# Altium schematic sheet CONN.SchDoc
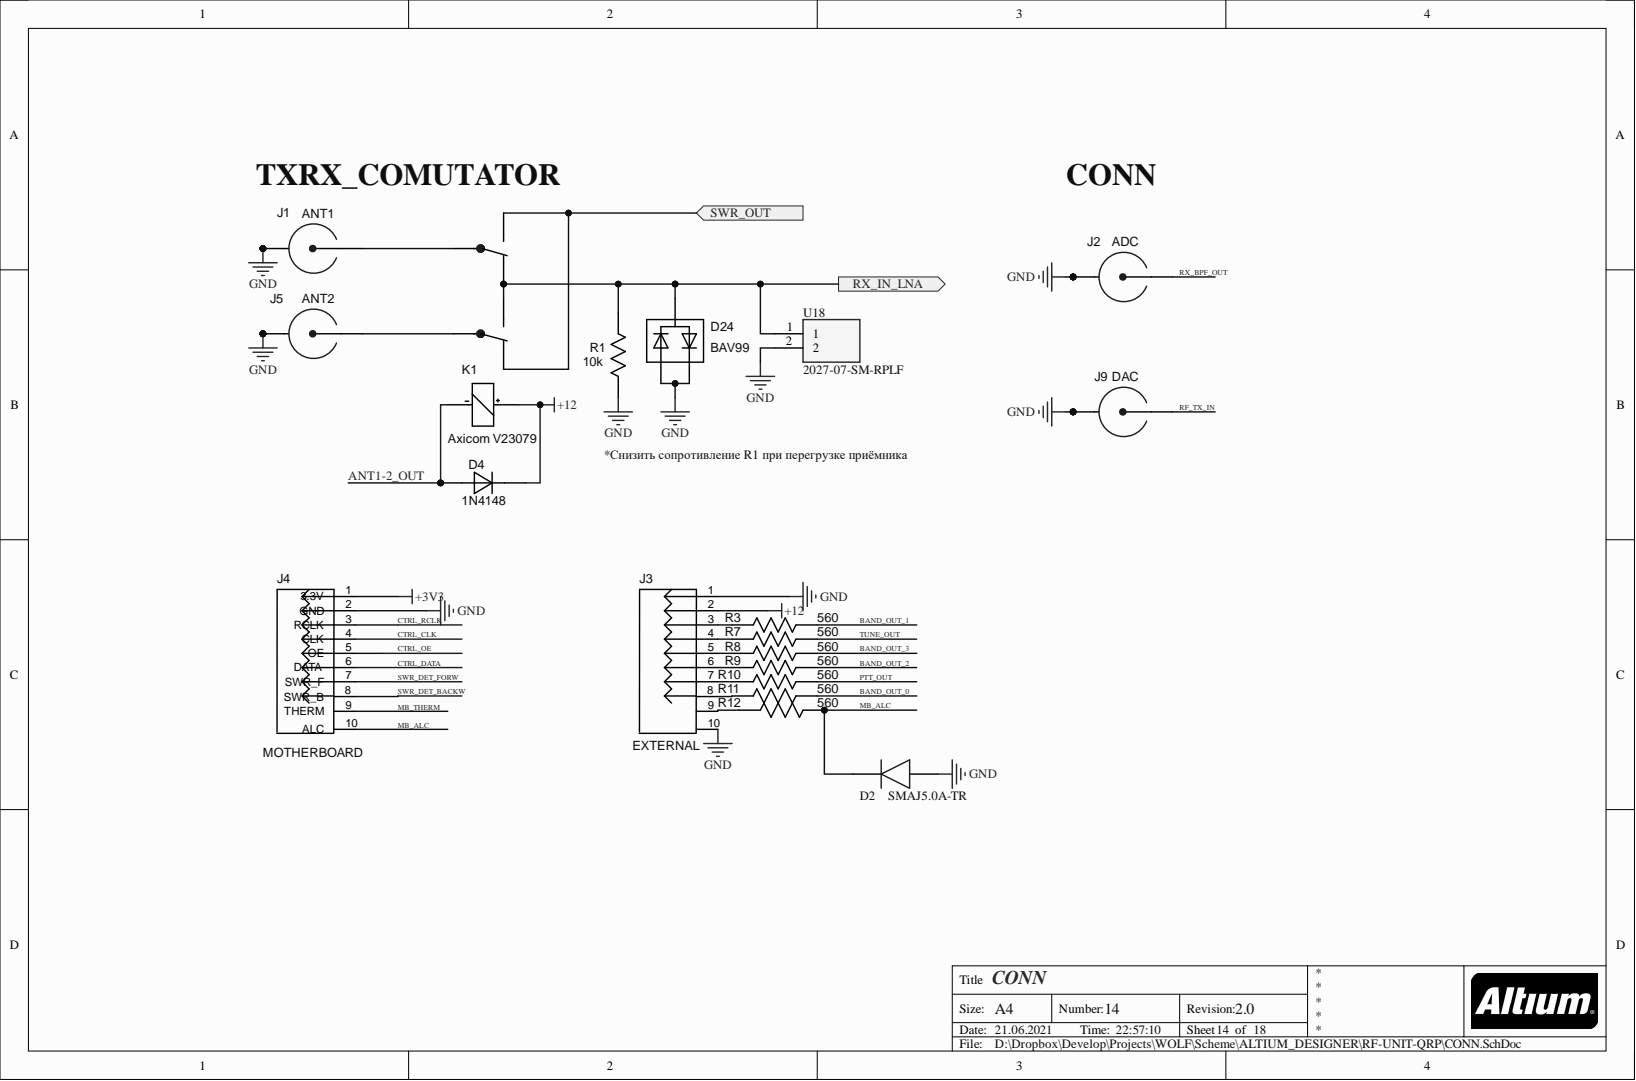
[diagram p0: CONN.SchDoc]

## Parts
D2  SMAJ5.0A-TR  [SMBJ36CATR]
D4  1N4148  [1N4148]
D24  BAV99  [SOT23]
J1  ANT1  [31-5431]
J2  ADC  [31-5431]
J3  EXTERNAL  [90131-0126]
J4  MOTHERBOARD  [90131-0126]
J5  ANT2  [31-5431]
J9  DAC  [31-5431]
K1  Axicom V23079  [V23079]
R1  10k  [RES_1206]
R3  560  [RES_0805]
R7  560  [RES_0805]
R8  560  [RES_0805]
R9  560  [RES_0805]
R10  560  [RES_0805]
R11  560  [RES_0805]
R12  560  [RES_0805]
U18  2027-07-SM-RPLF  [200307SMRPLF]

## Ports
RX_IN_LNA, SWR_OUT

## Nets
+3V3: J4.1(3.3V)
+12: D4.1 J3.2 K1.1
GND: D2.2 D24.1 D24.2 J1.1 J1.2 J1.3 J1.4 J2.1 J2.2 J2.3 J2.4 J3.1 J3.10 J4.2(GND) J5.1 J5.2 J5.3 J5.4 J9.1 J9.2 J9.3 J9.4 R1.1 U18.2
ANT1-2_OUT: D4.2 K1.8
BAND_OUT_0: R11.2
BAND_OUT_1: R3.2
BAND_OUT_2: R9.2
BAND_OUT_3: R8.2
CTRL_CLK: J4.4(CLK)
CTRL_DATA: J4.6(DATA)
CTRL_OE: J4.5(OE)
CTRL_RCLK: J4.3(RCLK)
MB_ALC: D2.1 J4.10(ALC) R12.2
MB_THERM: J4.9(THERM)
PTT_OUT: R10.2
RF_TX_IN: J9.5
RX_BPF_OUT: J2.5
RX_IN_LNA: D24.3 K1.4 K1.7 R1.2 U18.1 ; port RX_IN_LNA
SWR_DET_BACKW: J4.8(SWR_B)
SWR_DET_FORW: J4.7(SWR_F)
SWR_OUT: K1.2 K1.5 ; port SWR_OUT
TUNE_OUT: R7.2
NetJ1_5: J1.5 K1.6
NetJ3_3: J3.3 R3.1
NetJ3_4: J3.4 R7.1
NetJ3_5: J3.5 R8.1
NetJ3_6: J3.6 R9.1
NetJ3_7: J3.7 R10.1
NetJ3_8: J3.8 R11.1
NetJ3_9: J3.9 R12.1
NetJ5_5: J5.5 K1.3

## Library data of the parts
D2 = SMLVT3V3: Diode; Manufacturer_Name: STMicroelectronics; Allied_Number: 70013736; Allied Price/Stock: http://www.alliedelec.com/stmicroelectronics-smlvt3v3/70013736/; Component Kind: Standard; Datasheet Link: http://www.st.com/web/en/resource/technical/document/datasheet/CD00000923.pdf; Height: 2.65mm; Manufacturer_Part_Number: SMLVT3V3; Mouser Part Number: 511-SMLVT3V3; Mouser Price/Stock: https://www.mouser.com/Search/Refine.aspx?Keyword=511-SMLVT3V3; RS Part Number: 5444821P; RS Price/Stock: http://uk.rs-online.com/web/p/products/5444821P
J1 J2 J5 J9 = 31-5431: SMA; Component Kind: Standard; Library Name: RF-UNIT_1.SCHLIB
  J1: Value: ANT1
  J2: Value: ADC
  J5: Value: ANT2
  J9: Value: DAC
J3 J4 = 90131-0126: 2*5 PIN; Component Kind: Standard; Library Name: RF-UNIT.SchLib
R1 = RES_1206: Component Kind: Standard; Description: RES_1206
R3 R7-R12 = RES_0805: Component Kind: Standard; Description: RES_0805; Value: 560
U18 = 2003-07-SM-RPLF: Undefined or Miscellaneous; Manufacturer_Name: Bourns; Arrow Part Number: 2003-07-SM-RPLF; Arrow Price/Stock: https://www.arrow.com/en/products/2003-07-sm-rplf/bourns; Component Kind: Standard; Datasheet Link: https://www.arrow.com/en/products/2003-07-sm-rplf/bourns; Height: 3.7mm; Manufacturer_Part_Number: 2003-07-SM-RPLF; Mouser Part Number: 652-2003-07-SM-RPLF; Mouser Price/Stock: https://www.mouser.co.uk/ProductDetail/Bourns/2003-07-SM-RPLF?qs=j%252B1pi9TdxUaYVLyv%2F6mAaA%3D%3D
D4 = 1N4148: Component Kind: Standard; Description: 1N4148; Value: 1N4148
D24 = BAV99: Component Kind: Standard; Description: BAV99; Value: BAV99
K1 = Axicom V23079: Component Kind: Standard; Description: Axicom V23079; Library Name: RF-UNIT.SchLib
